# Altium schematic sheet Interface_V3.0.SchDoc
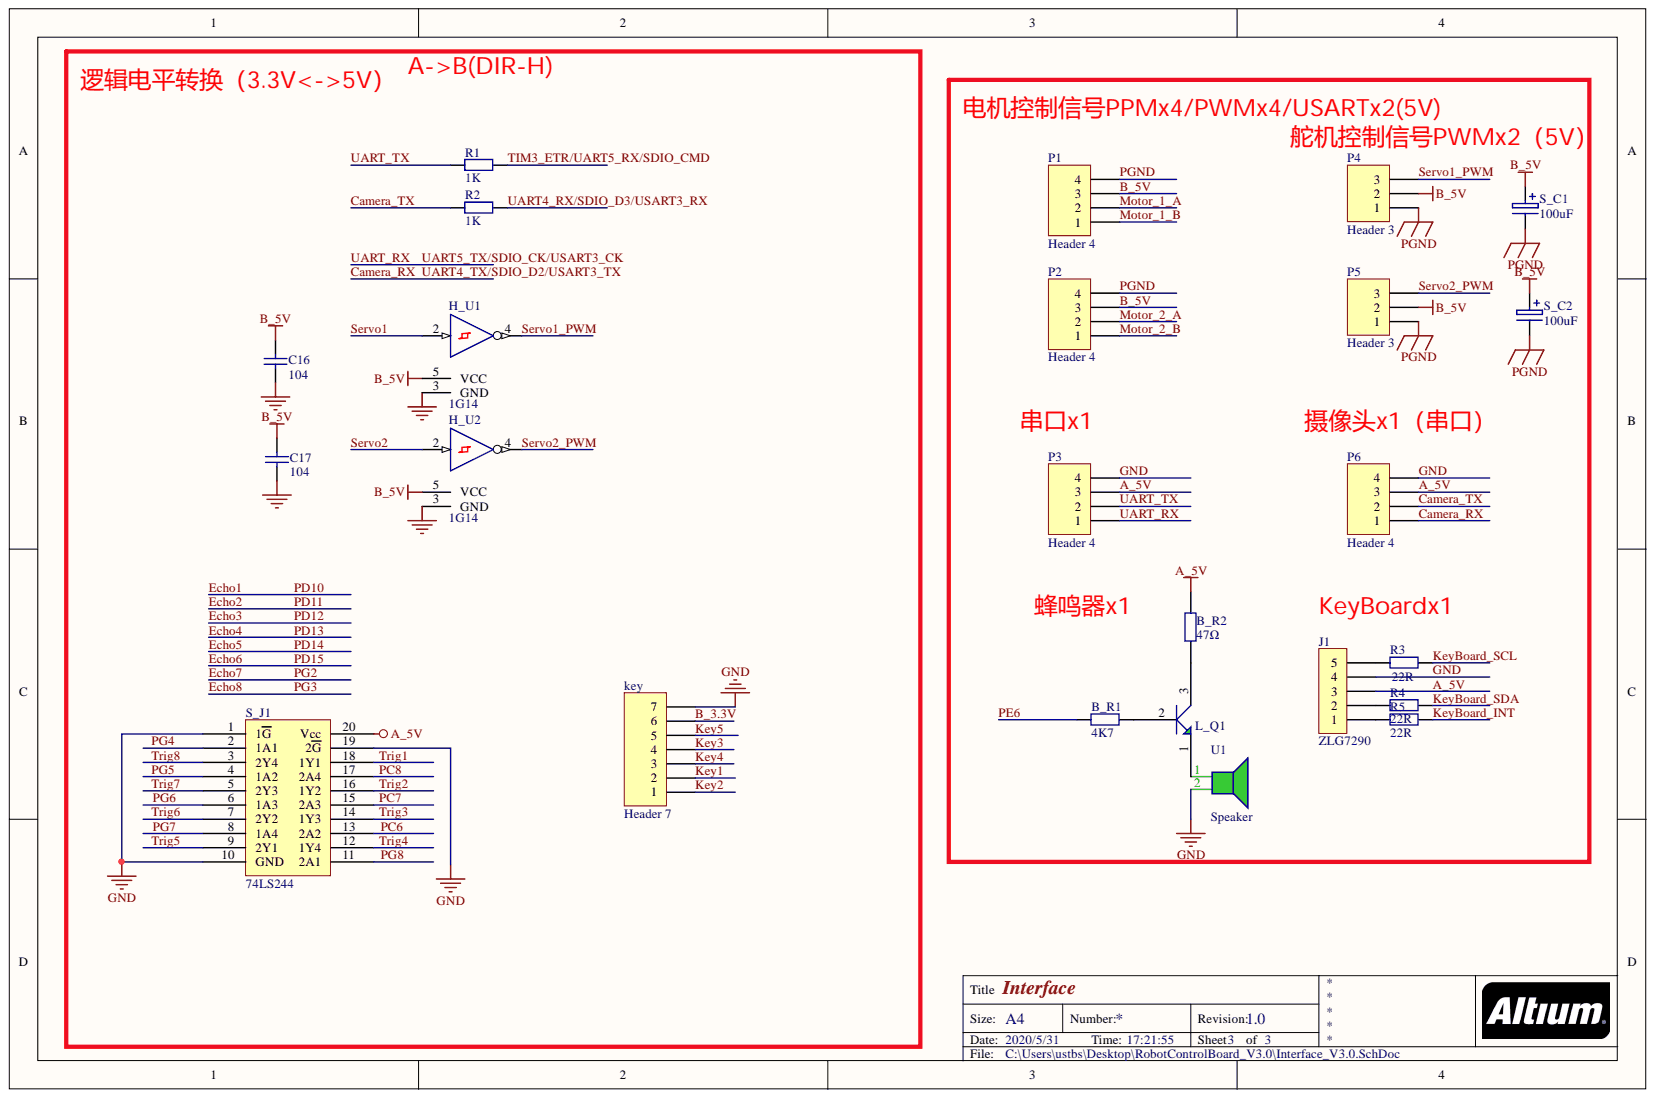
[diagram p0: Interface_V3.0.SchDoc]

## Parts
B_R1  value 4K7  [R0603]  (hidden comment: Res2)
B_R2  value 47Ω  [R0603]  (hidden comment: 1K)
C16  value 104  [C0603]  (hidden comment: Cap)
C17  value 104  [C0603]  (hidden comment: Cap)
H_U1  1G14  [SOT-23-5]
H_U2  1G14  [SOT-23-5]
J1  ZLG7290  [SIP-5]
key  Header 7  [HDR1X7]
L_Q1  1AMNPN9013  [CHIP]  (value not drawn)
P1  Header 4  [sip-4]
P2  Header 4  [sip-4]
P3  Header 4  [sip-4]
P4  Header 3  [SIP-3]
P5  Header 3  [SIP-3]
P6  Header 4  [sip-4]
R1  value 1K  [R0603]  (hidden comment: Res2)
R2  value 1K  [R0603]  (hidden comment: Res2)
R3  value 22R  [R0603]  (hidden comment: Res2)
R4  value 22R  [R0603]  (hidden comment: Res2)
R5  value 22R  [R0603]  (hidden comment: Res2)
S_C1  100uF  [C7343]
S_C2  100uF  [C7343]
S_J1  74LS244  [SSOP20]
U1  Speaker  [SPEAKER]

## Nets
A_5V: B_R2.1 J1.3 P3.3 P6.3 S_J1.20(Vcc)
B_3.3V: key.6
B_5V: C16.2 C17.2 H_U1.5(VCC) H_U2.5(VCC) P1.3 P2.3 P4.2 P5.2 S_C1.1 S_C2.1
Camera_RX: P6.1
Camera_TX: P6.2 R2.2
GND: C16.1 C17.1 H_U1.3(GND) H_U2.3(GND) J1.4 key.7 P3.4 P6.4 S_J1.1(1G) S_J1.10(GND) S_J1.19(2G) U1.2
Key1: key.2
Key2: key.1
Key3: key.4
Key4: key.3
Key5: key.5
KeyBoard_INT: R5.1
KeyBoard_SCL: R3.1
KeyBoard_SDA: R4.1
Motor_1_A: P1.2
Motor_1_B: P1.1
Motor_2_A: P2.2
Motor_2_B: P2.1
PC6: S_J1.13(2A2)
PC7: S_J1.15(2A3)
PC8: S_J1.17(2A4)
PE6: B_R1.2
PG4: S_J1.2(1A1)
PG5: S_J1.4(1A2)
PG6: S_J1.6(1A3)
PG7: S_J1.8(1A4)
PG8: S_J1.11(2A1)
PGND: P1.4 P2.4 P4.1 P5.1 S_C1.2 S_C2.2
Servo1: H_U1.2
Servo1_PWM: H_U1.4 P4.3
Servo2: H_U2.2
Servo2_PWM: H_U2.4 P5.3
TIM3_ETR/UART5_RX/SDIO_CMD: R1.1
Trig1: S_J1.18(1Y1)
Trig2: S_J1.16(1Y2)
Trig3: S_J1.14(1Y3)
Trig4: S_J1.12(1Y4)
Trig5: S_J1.9(2Y1)
Trig6: S_J1.7(2Y2)
Trig7: S_J1.5(2Y3)
Trig8: S_J1.3(2Y4)
UART4_RX/SDIO_D3/USART3_RX: R2.1
UART5_TX/SDIO_CK/USART3_CK: P3.1
UART_TX: P3.2 R1.2
NetB_R1_1: B_R1.1 L_Q1.2
NetB_R2_2: B_R2.2 L_Q1.3
NetJ1_1: J1.1 R5.2
NetJ1_2: J1.2 R4.2
NetJ1_5: J1.5 R3.2
NetL_Q1_1: L_Q1.1 U1.1
Echo1:
Echo2:
Echo3:
Echo4:
Echo5:
Echo6:
Echo7:
Echo8:

## Library data of the parts
B_R1 R1-R5 = Res2: Resistor; Component Kind: Standard; LatestRevisionDate: 17-Jul-2002; LatestRevisionNote: Re-released for DXP Platform.; Library Name: Free Documents.IntLib; PackageReference: AXIAL-0.4; Published: 8-Jun-2000; Signal Integrity: Res; Simulation: RESISTOR; Publisher: Altium Limited
B_R2 = RES: Resistor; Manufacturer: Generic Components; Class: Passive; Component Kind: Standard; Library Name: Free Documents.IntLib; Published: 08/06/2000; Signal Integrity: Res2; Simulation: RESISTOR; SubClass: Resistor; Publisher: Altium Hobart Technology Centre
C16 C17 = Cap: Capacitor; Component Kind: Standard; LatestRevisionDate: 17-Jul-2002; LatestRevisionNote: Re-released for DXP Platform.; Library Name: Miscellaneous Devices.IntLib; PackageDescription: Radial Cap, Thru-Hole; 2 Leads; 0.3 in Pin Spacing; PackageReference: RAD-0.3; Published: 8-Jun-2000; Signal Integrity: Cap; Simulation: CAP; Publisher: Altium Limited
H_U1 H_U2 = 74V1G14: Component Kind: Standard; Library Name: Eight_1.IntLib
J1 = CON5: Connector; Component Kind: Standard
key = Header 7: Header, 7-Pin; Component Kind: Standard; LatestRevisionDate: 17-Jul-2002; LatestRevisionNote: Re-released for DXP Platform.; Library Name: Miscellaneous Connectors.IntLib; Signal Integrity: Connector; Publisher: Altium Limited
L_Q1 = 9013: Component Kind: Standard; Library Name: Free Documents.IntLib
P1-P3 P6 = Header 4: Header, 4-Pin; Component Kind: Standard; LatestRevisionDate: 17-Jul-2002; LatestRevisionNote: Re-released for DXP Platform.; Library Name: Miscellaneous Connectors.IntLib; Signal Integrity: Connector; Publisher: Altium Limited
P4 P5 = Header 3: Header, 3-Pin; Component Kind: Standard; LatestRevisionDate: 17-Jul-2002; LatestRevisionNote: Re-released for DXP Platform.; Library Name: Miscellaneous Connectors.IntLib; Signal Integrity: Connector; Publisher: Altium Limited
S_C1 S_C2 = ELECTRO: Electrolytic Capacitor; Component Kind: Standard; Library Name: PCB_Project2.IntLib
S_J1 = 74LS244: Component Kind: Standard; Library Name: main board 4.1.IntLib
U1 = Speaker: Component Kind: Standard; Library Name: Free Documents.IntLib
